# Altium schematic sheet BIG_5160.SchDoc
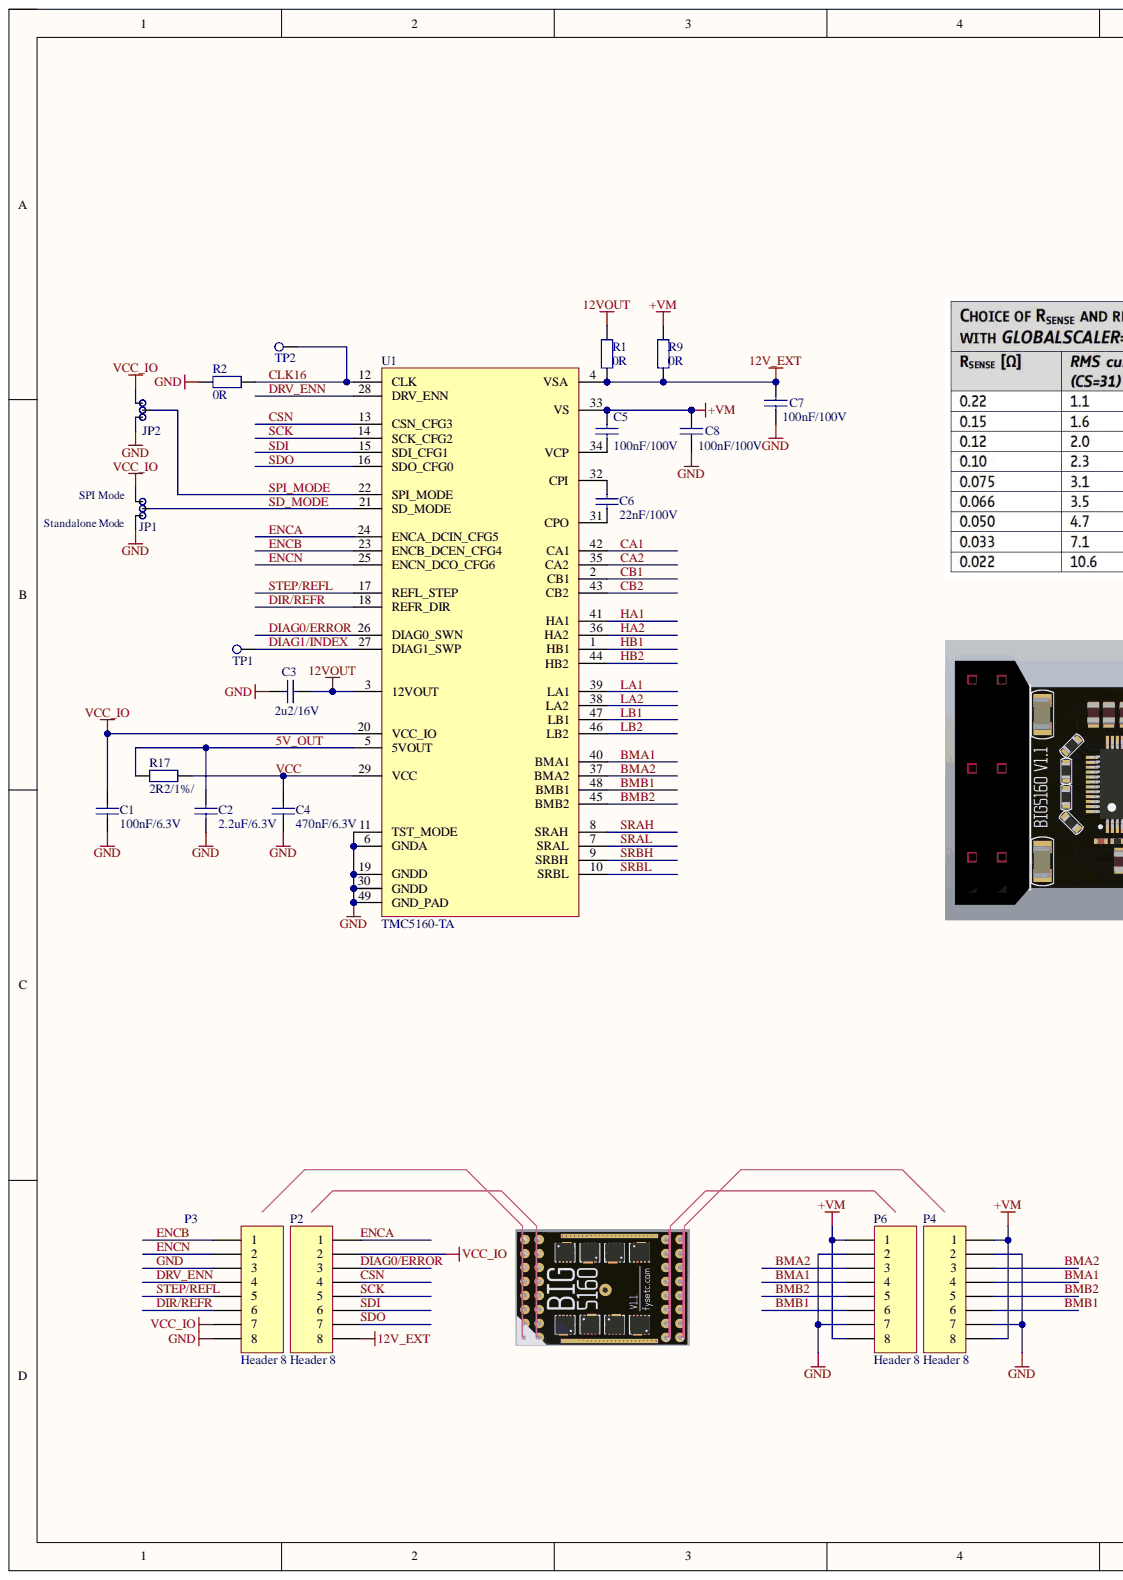
[diagram p0: BIG_5160.SchDoc, left]
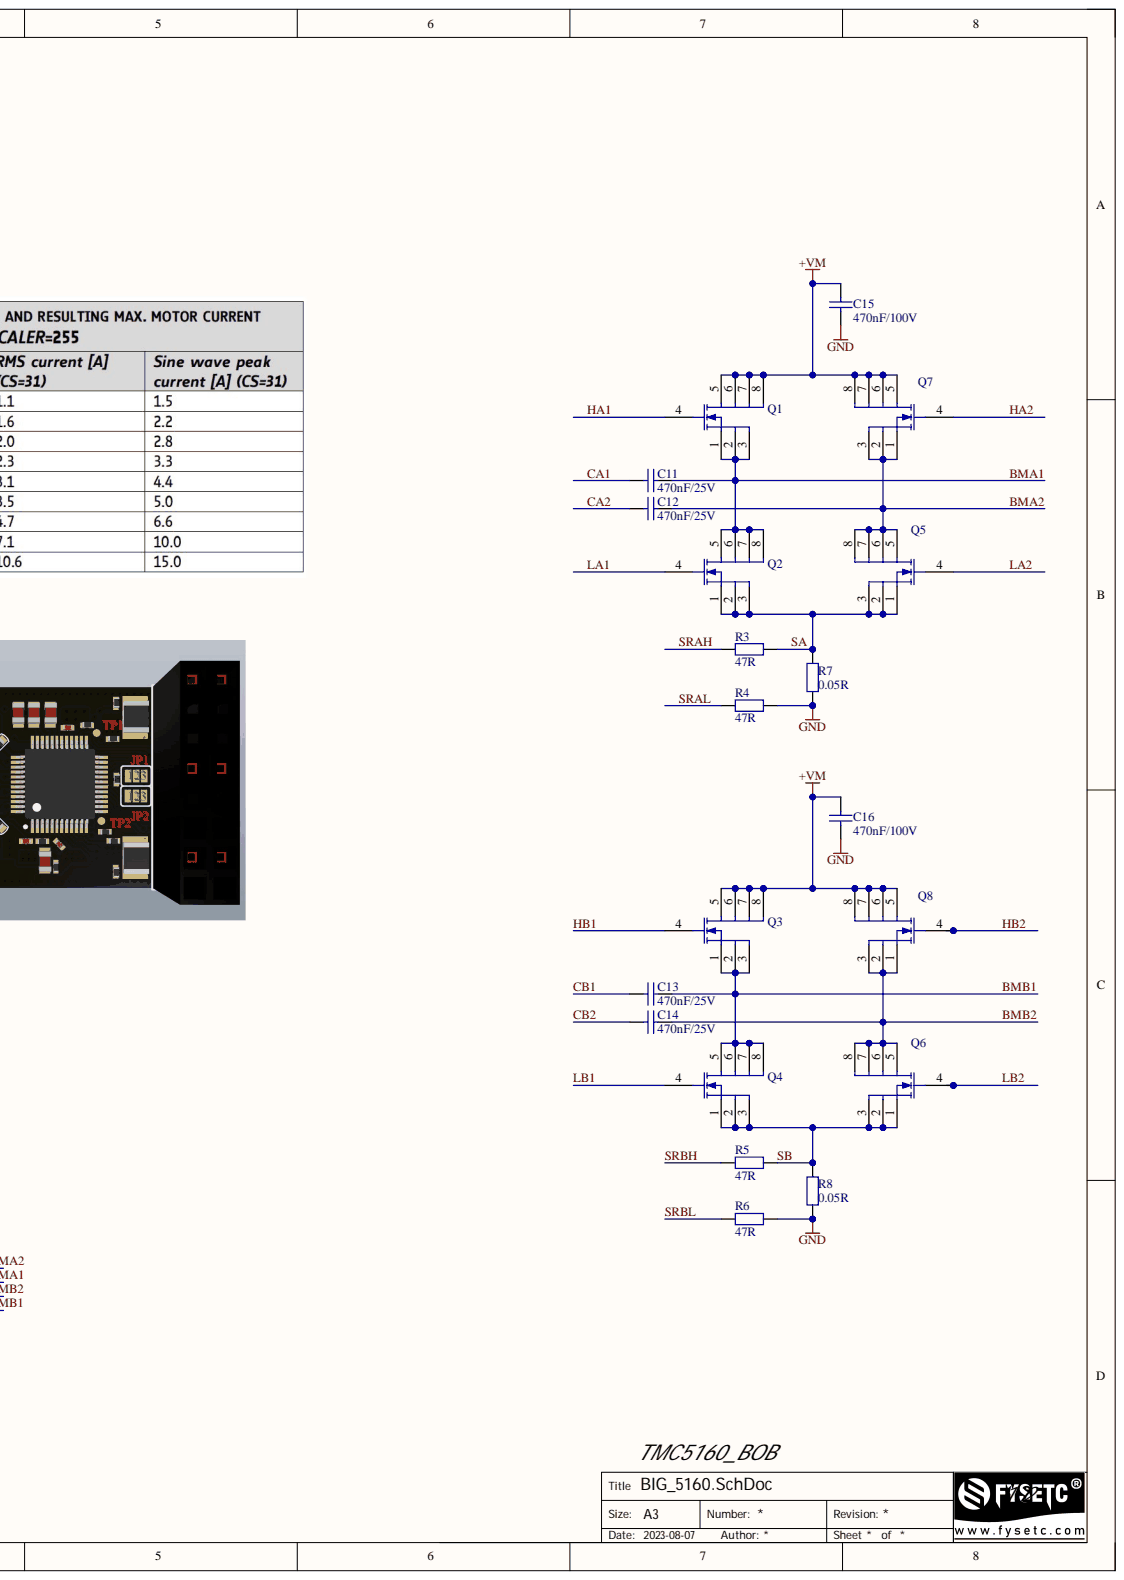
[diagram p1: BIG_5160.SchDoc, right]

## Parts
C1  100nF/6.3V  [RES0402_NS]
C2  2.2uF/6.3V  [CAP0402_NS]
C3  2u2/16V  [CAP0402_NS]
C4  470nF/6.3V  [CAP0402_NS]
C5  100nF/100V  [CAP0805_NS]
C6  Capacitance: 22nF/100V  [CAP0805_NS]
C7  100nF/100V  [CAP0805_NS]
C8  100nF/100V  [CAP0805_NS]
C11  470nF/25V  [CAP0603]
C12  470nF/25V  [CAP0603]
C13  470nF/25V  [CAP0603]
C14  470nF/25V  [CAP0603]
C15  470nF/100V  [CAP1206]
C16  470nF/100V  [CAP1206]
JP1  Jumper-3P  [PAD-JUMPER-3-2OF3_NC_BY_PASTE_YES_SILK_FULL_BOX]  (value not drawn)
JP2  Jumper-3P  [PAD-JUMPER-3-2OF3_NC_BY_PASTE_YES_SILK_FULL_BOX]  (value not drawn)
P2  Header 8  [Header 1X8]
P3  Header 8  [Header 1X8]
P4  Header 8  [Header 1X8]
P6  Header 8  [Header 1X8]
Q1  80V/60A  [DFN3X3-8L]  (value not drawn)
Q2  80V/60A  [DFN3X3-8L]  (value not drawn)
Q3  80V/60A  [DFN3X3-8L]  (value not drawn)
Q4  80V/60A  [DFN3X3-8L]  (value not drawn)
Q5  80V/60A  [DFN3X3-8L]  (value not drawn)
Q6  80V/60A  [DFN3X3-8L]  (value not drawn)
Q7  80V/60A  [DFN3X3-8L]  (value not drawn)
Q8  80V/60A  [DFN3X3-8L]  (value not drawn)
R1  Resistance: 0R  [RES0402_NS]  (hidden comment: 0R /  / 1%)
R2  Resistance: 0R  [RES0402_NS]  (hidden comment: 0R /  / 1%)
R3  Resistance: 47R  [RES0402_NS]  (hidden comment: 47R /  / 1%)
R4  Resistance: 47R  [RES0402_NS]  (hidden comment: 47R /  / 1%)
R5  Resistance: 47R  [RES0402_NS]  (hidden comment: 47R /  / 1%)
R6  Resistance: 47R  [RES0402_NS]  (hidden comment: 47R /  / 1%)
R7  Resistance: 0.05R  [RES1210]  (hidden comment: 75mR)
R8  Resistance: 0.05R  [RES1210]  (hidden comment: 75mR)
R9  Resistance: 0R  [RES0402_NS]  (hidden comment: NC/0R)
R17  2R2/1%/  [RES0402_NS]
TP1  Testpoint  [Testpoint]  (value not drawn)
TP2  Testpoint  [Testpoint]  (value not drawn)
U1  TMC5160-TA  [eTQFP48]

## Nets
12V_EXT: C7.1 P2.8 R1.2 R9.2 U1.4(VSA)
12VOUT: C3.2 R1.1 U1.3(12VOUT)
+VM: C5.1 C8.1 C15.1 C16.1 P4.1 P4.8 P6.1 P6.8 Q1.5 Q1.6 Q1.7 Q1.8 Q3.5 Q3.6 Q3.7 Q3.8 Q7.5 Q7.6 Q7.7 Q7.8 Q8.5 Q8.6 Q8.7 Q8.8 R9.1 U1.33(VS)
VCC_IO: C1.1 JP1.1 JP2.1 P2.2 P3.7 U1.20(VCC_IO)
5V_OUT: C2.1 R17.1 U1.5(5VOUT)
BMA1: C11.2 P4.4 P6.4 Q1.1 Q1.2 Q1.3 Q2.5 Q2.6 Q2.7 Q2.8 U1.40(BMA1)
BMA2: C12.2 P4.3 P6.3 Q5.5 Q5.6 Q5.7 Q5.8 Q7.1 Q7.2 Q7.3 U1.37(BMA2)
BMB1: C13.2 P4.6 P6.6 Q3.1 Q3.2 Q3.3 Q4.5 Q4.6 Q4.7 Q4.8 U1.48(BMB1)
BMB2: C14.2 P4.5 P6.5 Q6.5 Q6.6 Q6.7 Q6.8 Q8.1 Q8.2 Q8.3 U1.45(BMB2)
CA1: C11.1 U1.42(CA1)
CA2: C12.1 U1.35(CA2)
CB1: C13.1 U1.2(CB1)
CB2: C14.1 U1.43(CB2)
CLK16: R2.2 TP2.1 U1.12(CLK)
CSN: P2.4 U1.13(CSN_CFG3)
DIAG0/ERROR: P2.3 U1.26(DIAG0_SWN)
DIAG1/INDEX: TP1.1 U1.27(DIAG1_SWP)
DIR/REFR: P3.6 U1.18(REFR_DIR)
DRV_ENN: P3.4 U1.28(DRV_ENN)
ENCA: P2.1 U1.24(ENCA_DCIN_CFG5)
ENCB: P3.1 U1.23(ENCB_DCEN_CFG4)
ENCN: P3.2 U1.25(ENCN_DCO_CFG6)
GND: C1.2 C2.2 C3.1 C4.2 C7.2 C8.2 C15.2 C16.2 JP1.3 JP2.3 P3.3 P3.8 P4.2 P4.7 P6.2 P6.7 R2.1 R4.1 R6.1 R7.2 R8.2 U1.6(GNDA) U1.11(TST_MODE) U1.19(GNDD) U1.30(GNDD) U1.49(GND_PAD)
HA1: Q1.4 U1.41(HA1)
HA2: Q7.4 U1.36(HA2)
HB1: Q3.4 U1.1(HB1)
HB2: Q8.4 U1.44(HB2)
LA1: Q2.4 U1.39(LA1)
LA2: Q5.4 U1.38(LA2)
LB1: Q4.4 U1.47(LB1)
LB2: Q6.4 U1.46(LB2)
SA: Q2.1 Q2.2 Q2.3 Q5.1 Q5.2 Q5.3 R3.1 R7.1
SB: Q4.1 Q4.2 Q4.3 Q6.1 Q6.2 Q6.3 R5.1 R8.1
SCK: P2.5 U1.14(SCK_CFG2)
SD_MODE: JP1.2 U1.21(SD_MODE)
SDI: P2.6 U1.15(SDI_CFG1)
SDO: P2.7 U1.16(SDO_CFG0)
SPI_MODE: JP2.2 U1.22(SPI_MODE)
SRAH: R3.2 U1.8(SRAH)
SRAL: R4.2 U1.7(SRAL)
SRBH: R5.2 U1.9(SRBH)
SRBL: R6.2 U1.10(SRBL)
STEP/REFL: P3.5 U1.17(REFL_STEP)
VCC: C4.1 R17.2 U1.29(VCC)
NetC5_2: C5.2 U1.34(VCP)
NetC6_1: C6.1 U1.31(CPO)
NetC6_2: C6.2 U1.32(CPI)

## Library data of the parts
C1 = Capacitor: Ceramic capacitor; Manufacturer: Multicomp; MPN: MC0603F104M500CT; Capacitance: 100nF; ComponentLinknDescription: Datasheet; Precision: 20%; Type: MLCC; Voltage rating: 50V; Component Kind: Standard; Library Name: SCH Passives.SchLib
C2 C3 = 2.2 µF, 25 V, ± 10%, X5R, GRM Series: SMD Multilayer Ceramic Capacitor, 0603 [1608 Metric], 2.2 µF, 25 V, ± 10%, X5R, GRM Series; MPN: GRM188R61E225KA12D; Capacitance: 2u2; ComponentLinknDescription: Datasheet; MF: MURATA; Type: MLCC; Voltage rating: 50V; Component Kind: Standard; Library Name: TMC5160_RevA.SchLib; Precision: 10%
C4 = Capacitor: Ceramic capacitor; MPN: MC0603X474K160CT; Capacitance: 470nF; ComponentLinknDescription: Datasheet; MF: Multicomp; Precision: 10%; Type: MLCC; Voltage rating: 16V; Component Kind: Standard; Library Name: SCH Passives.SchLib
C5 = Capacitor: Ceramic capacitor; MPN: MC0603F104M500CT; ComponentLinknDescription: Datasheet; MF: Multicomp; Precision: 20%; Type: MLCC; Voltage rating: 63V; Capacitance: 100nF/100V; Component Kind: Standard; Library Name: SCH Passives.SchLib
C6 = Capacitor: Ceramic capacitor; MPN: MC0603B223K500CT; ComponentLinknDescription: Datasheet; MF: Multicomp; Precision: 20%; Type: MLCC; Voltage_rating: 100V; Component Kind: Standard; Library Name: SCH Passives.SchLib
C7 = Capacitor: Ceramic capacitor; Manufacturer: Multicomp; MPN: MC0603F104M500CT; ComponentLinknDescription: Datasheet; Precision: 20%; Type: MLCC; Voltage rating: 63V; Capacitance: 100nF/100V; Component Kind: Standard; Library Name: SCH Passives.SchLib
C8 = Capacitor: Ceramic capacitor; Manufacturer: Multicomp; MPN: MC0603F104M500CT; Capacitance: 100nF; ComponentLinknDescription: Datasheet; Precision: 20%; Type: MLCC; Voltage rating: 63V; Component Kind: Standard; Library Name: SCH Passives.SchLib
C11-C14 = 0.22 µF, 50 V, ± 10%, X7R: SMD Multilayer Ceramic Capacitor, 0603 [1608 Metric], 0.22 µF, 50 V, ± 10%, X7R, GCM Series; MPN: GCM188R71H224KA64D; ComponentLinknDescription: Datasheet; MF: MURATA; Type: MLCC; Voltage rating: 50V; Capacitance: 470nF/25V; Component Kind: Standard; Library Name: TMC5160_RevA.SchLib; Precision: 10%
C15 C16 = 0.47 µF, 50 V, ± 10%, X7R, C Series: SMD Multilayer Ceramic Capacitor, 0603 [1608 Metric], 0.47 µF, 50 V, ± 10%, X7R, C Series; MPN: C1608X7R1H474K080AC; ComponentLinknDescription: Datasheet; MF: TDK; Type: MLCC; VoltageRating: 63V; Capacitance: 470nF/100V; Component Kind: Standard; Library Name: TMC5160_RevA.SchLib; Precision: 10%
P2-P4 P6 = Header 8: Header, 8-Pin; Component Kind: Standard; LatestRevisionDate: 17-Jul-2002; LatestRevisionNote: Re-released for DXP Platform.; Library Name: Miscellaneous Connectors.IntLib; Signal Integrity: Connector; Publisher: Altium Limited
Q1-Q8 = MOSFET-N-SO8: Faber ItemCode: 36926000; ID: LIBRARY_FET-0002; Info: HEXFET Power MOSFET; Mfg: INTERNATIONAL RECTIFIER; Mfg code: IRF8736PBF; Value: S6606; Component Kind: Standard; Library Name: Ultimaker2.SCHLIB
R1-R6 R9 = 47 ohm, 75 V, 0603 [1608 Metric], 100 mW, ± 1%, MCSR 06 Series: SMD Chip Resistor, Ceramic, 47 ohm, 75 V, 0603 [1608 Metric], 100 mW, ± 1%, MCSR 06 Series; MPN: MCSR06X47R0FTL; MF: MULTICOMP; Temp coefficient: *ppm; Component Kind: Standard; Library Name: TMC5160_RevA.SchLib; Precision: 1%
R7 R8 = R_SENS, 0.075 ohm, 1206, 1W, ± 1%, ERJ Series: SMD Chip Resistor, Thick Film, Current Sense Resistors - SMD 1W 0.075ohm 1%; MPN: ERJ-8BWFR075V; MF: Panasonic Electronic Components; Temp coefficient: *ppm; VoltageRating: 50V; Component Kind: Standard; Library Name: SMD_Passives.SchLib; PowerRating: 1W; Precision: 1%
R17 = Resistor: MPN: MC0063W060312R2; ComponentLinknDescription: Datasheet; MF: Multicomp; Power rating: *mW; Precision: 1%; Resistance: 2R2; Temp coefficient: *ppm; Voltage rating: *V; Component Kind: Standard; Description: Resistor; Library Name: SCH Passives.SchLib
TP1 TP2 = Testpoint_1: ID: Library_MISC-0001; Info: Testpoint, 2mm, round; Component Kind: Standard; Description: Testpoint; Faber ItemCode: -; Mfg: -; Mfg code: -; Value: Testpoint; Library Name: Ultimaker2.SCHLIB
U1 = TMC5160-TA: Manufacturer: TRINAMIC; Geometry.Height: 1,2mm; Component Kind: Standard; Distributor#1: Digikey; MF: TRINAMIC; MPN: TMC5160-TA; Library Name: 接插件库.SCHLIB
JP1 JP2 = Jumper-3P: Component Kind: Standard; Library Name: 接插件库.SCHLIB
